# Altium schematic sheet 03_Power.SchDoc(03_Power)
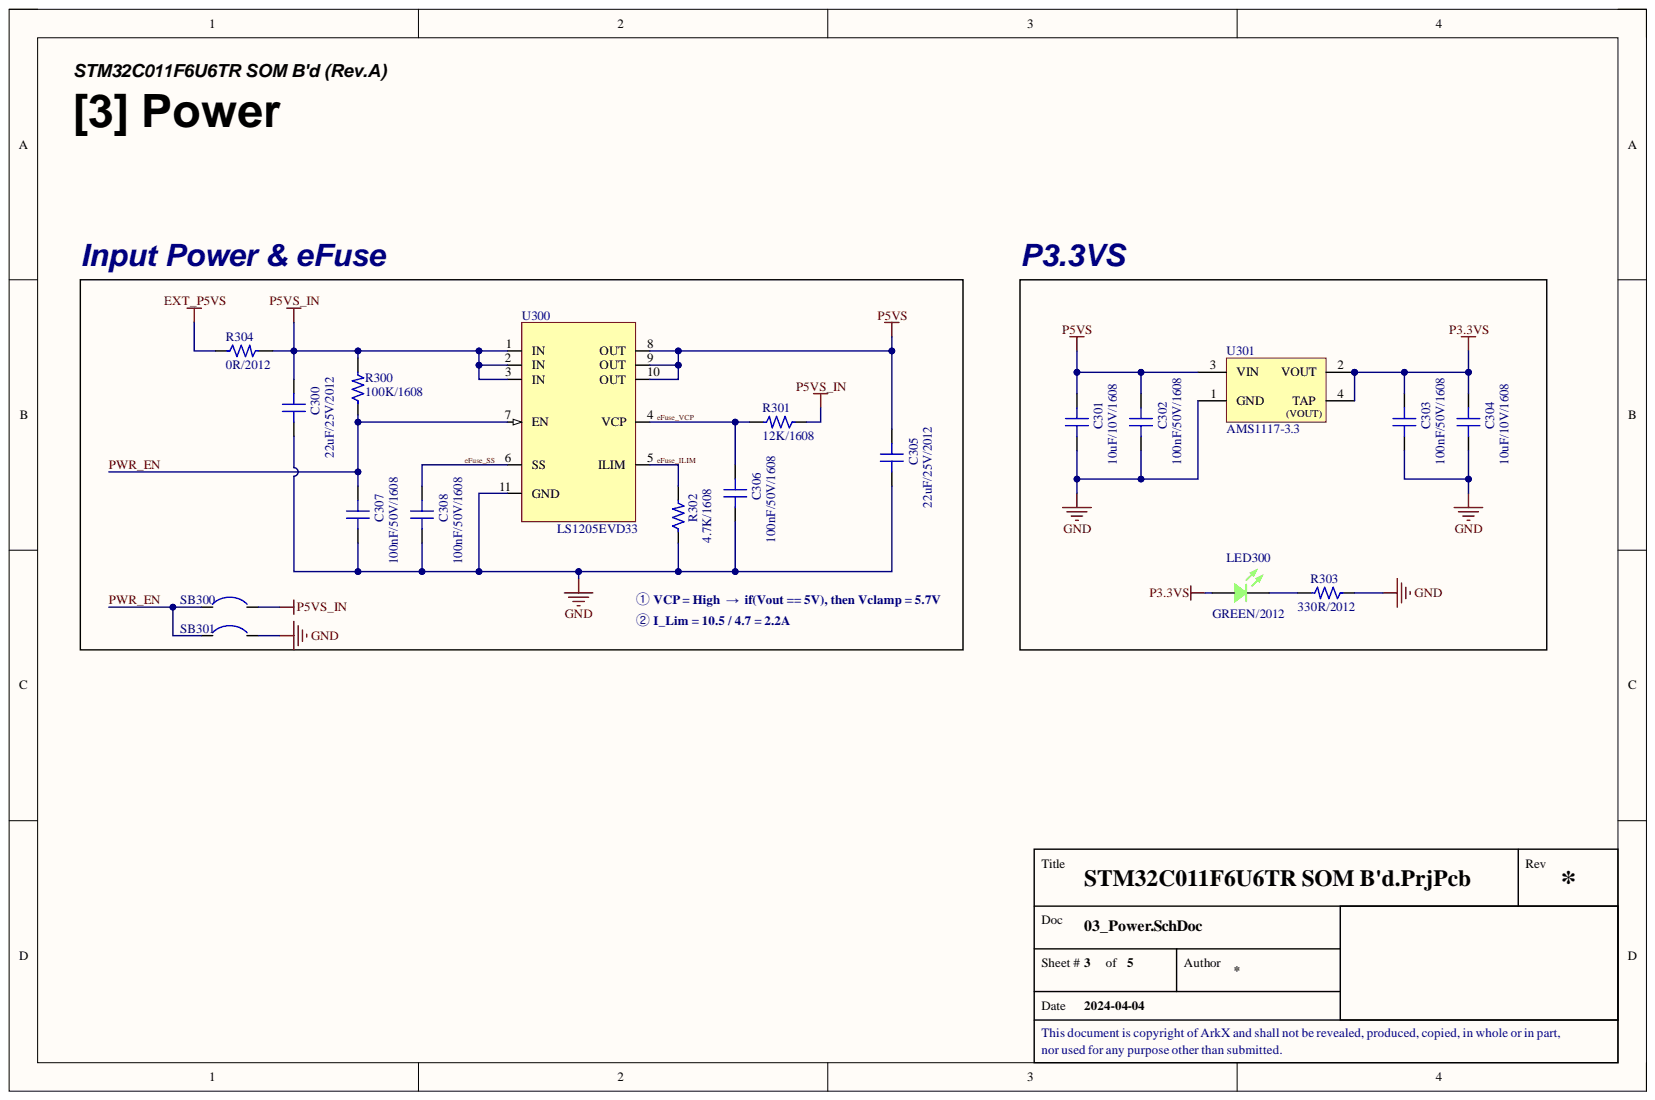
[diagram p0: 03_Power.SchDoc]

## Parts
C300  22uF/25V/2012  [CAP 2012 (0805_INCH)]
C301  10uF/10V/1608  [CAP 1608 (0603_INCH)]
C302  100nF/50V/1608  [CAP 1608 (0603_INCH)]
C303  100nF/50V/1608  [CAP 1608 (0603_INCH)]
C304  10uF/10V/1608  [CAP 1608 (0603_INCH)]
C305  22uF/25V/2012  [CAP 2012 (0805_INCH)]
C306  100nF/50V/1608  [CAP 1608 (0603_INCH)]
C307  100nF/50V/1608  [CAP 1608 (0603_INCH)]
C308  100nF/50V/1608  [CAP 1608 (0603_INCH)]
LED300  GREEN/2012  [LED MHT170RGBCT (GREEN)]
R300  100K/1608  [RES 1608 (0603_inch)]
R301  12K/1608  [RES 1608 (0603_inch)]
R302  4.7K/1608  [RES 1608 (0603_inch)]
R303  330R/2012  [RES 2012 (0805_inch)]
R304  0R/2012  [RES 2012 (0805_inch)]
SB300  SB  [SB (Solder-Bridge)]
SB301  SB  [SB (Solder-Bridge)]
U300  LS1205EVD33  [IC_DFN_3x3_10L]
U301  AMS1117-3.3  [SOT 223-3 (DIODES INCORPORATED)]

## Nets
EXT_P5VS: R304.1
GND: C300.1 C301.1 C302.1 C303.1 C304.1 C305.1 C306.1 C307.1 C308.1 R302.1 R303.1 SB301.2 U300.11(GND) U301.1(GND)
P3.3VS: C303.2 C304.2 LED300.4 U301.2(VOUT) U301.4(TAP)
P5VS: C301.2 C302.2 C305.2 U300.8(OUT) U300.9(OUT) U300.10(OUT) U301.3(VIN)
P5VS_IN: C300.2 R300.2 R301.1 R304.2 SB300.2 U300.1(IN) U300.2(IN) U300.3(IN)
eFuse_ILIM: R302.2 U300.5(ILIM)
eFuse_SS: C308.2 U300.6(SS)
eFuse_VCP: C306.2 R301.2 U300.4(VCP)
PWR_EN: C307.2 R300.1 SB300.1 SB301.1 U300.7(EN)
NetLED300_2: LED300.2 R303.2

## Library data of the parts
C300 C305 = CAP_22uF/25V/2012: Ceramic CAP_22uF/25V/2012; Component Kind: Standard; JLCPCB Part Type: Basic Part; LCSC Part #: C45783; Library Name: Arkx.IntLib
C301 C304 = CAP_10uF/10V/1608: Ceramic CAP_10uF/10V/1608; Component Kind: Standard; JLCPCB Part Type: Basic Part; LCSC Part #: C19702; Library Name: Arkx.IntLib
C302 C303 C306-C308 = CAP_100nF/50V/1608: Ceramic CAP_100nF/50V/1608; Component Kind: Standard; JLCPCB Part Type: Basic Part; LCSC Part #: C14663; Library Name: Arkx.IntLib
LED300 = LED_MHT170RGBCT(GREEN): Colorless transparence -30℃~+85℃ Positive post Common anode RGB 120° 0805  Light Emitting Diodes (LED) ROHS; Component Kind: Standard; JLCPCB Part Type: Extended Part; LCSC Part #: C2962094; Library Name: Arkx.IntLib
R300 = RES_100K/1608/0.1W: Power Rating: 0.1W; Precision: 1%; Value: 100K; Component Kind: Standard; Description: RES_100K/1608/0.1W; JLCPCB Part Type: Basic Part; LCSC Part #: C25803; Size: 1608; Library Name: Arkx.IntLib
R301 = RES_12K/1608/0.1W: Power Rating: 0.1W; Precision: 1%; Value: 12K; Component Kind: Standard; Description: RES_12K/1608/0.1W; JLCPCB Part Type: Basic Part; LCSC Part #: C22790; Size: 1608; Library Name: Arkx.IntLib
R302 = RES_4.7K/1608/0.1W: Power Rating: 0.1W; Precision: 1%; Value: 4.7K; Component Kind: Standard; Description: RES_4.7K/1608/0.1W; JLCPCB Part Type: Basic Part; LCSC Part #: C23162; Size: 1608; Library Name: Arkx.IntLib
R303 = RES_330R/2012/0.125W: Power Rating: 0.125W; Precision: 1%; Value: 330R; Component Kind: Standard; Description: RES_330R/2012/0.125W; JLCPCB Part Type: Basic Part; LCSC Part #: C17630; Size: 2012; Library Name: Arkx.IntLib
R304 = RES_0R/2012/0.125W: Power Rating: 0.1W; Precision: 1%; Value: 0R; Component Kind: Standard; Description: RES_0R/2012/0.125W; JLCPCB Part Type: Basic Part; LCSC Part #: C17477; Size: 1608; Library Name: Arkx.IntLib
SB300 SB301 = SB_Solder-Bridge: Component Kind: Standard; Description: SB_Solder-Bridge; Library Name: Arkx.IntLib
U300 = IC_eFUSE_LS1205EVD33: 18V, 5A eFuse with Programmable Current Limit and Output Voltage Clamp, 10-DFN (3x3); Component Kind: Standard; Library Name: Arkx.IntLib
U301 = IC_REG_AMS1117-3.3: 72dB@(120Hz) 1A 1.3V@(800mA) Fixed 3.3V~3.3V Positive 1 SOT-223  Linear Voltage Regulators (LDO) ROHS; Component Kind: Standard; JLCPCB Part Type: Basic Part; LCSC Part #: C6186; Library Name: Arkx.IntLib
